# Altium schematic sheet bmsMaster_isospi.SchDoc
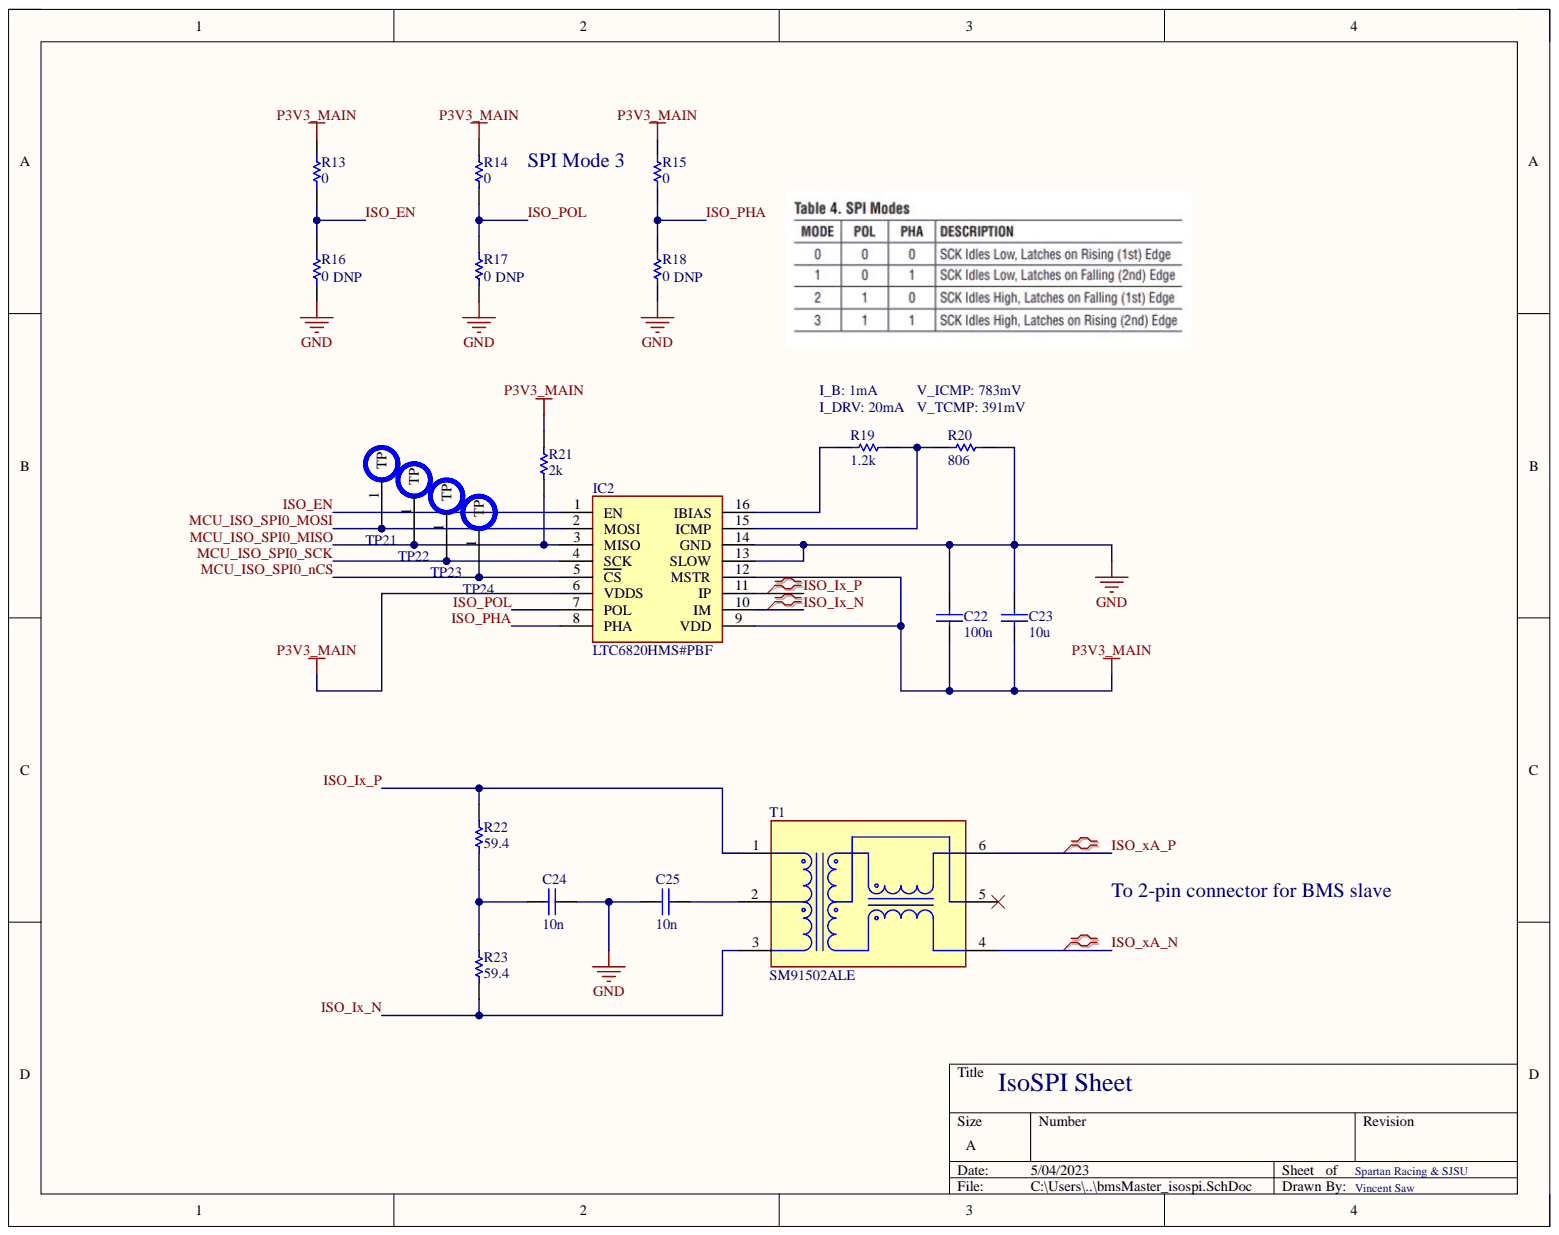
[diagram p0: bmsMaster_isospi.SchDoc]

## Parts
C22  value 100n  (hidden comment: Capacitor)
C23  value 10u  (hidden comment: Capacitor)
C24  value 10n  (hidden comment: Capacitor)
C25  value 10n  (hidden comment: Capacitor)
IC2  LTC6820HMS#PBF  [SOP50P490X110-16N]
R13  value 0  (hidden comment: Resistor)
R14  value 0  (hidden comment: Resistor)
R15  value 0  (hidden comment: Resistor)
R16  value 0  (hidden comment: Resistor)
R17  value 0  (hidden comment: Resistor)
R18  value 0  (hidden comment: Resistor)
R19  value 1.2k  (hidden comment: Resistor)
R20  value 806  (hidden comment: Resistor)
R21  value 2k  (hidden comment: Resistor)
R22  value 59.4  (hidden comment: Resistor)
R23  value 59.4  (hidden comment: Resistor)
T1  SM91502ALE  [FP-SM91502ALE-MFG]
TP21  TSTPT  [Testpoint1]  (value not drawn)  (no BOM)
TP22  TSTPT  [Testpoint1]  (value not drawn)  (no BOM)
TP23  TSTPT  [Testpoint1]  (value not drawn)  (no BOM)
TP24  TSTPT  [Testpoint1]  (value not drawn)  (no BOM)

## Nets
GND: C22.2 C23.2 C24.1 C25.1 IC2.13(SLOW) IC2.14(GND) R16.1 R17.1 R18.1 R20.2
P3V3_MAIN: C22.1 C23.1 IC2.6(VDDS) IC2.9(VDD) IC2.12(MSTR) R13.2 R14.2 R15.2 R21.2
ISO_EN: IC2.1(EN) R13.1 R16.2
ISO_Ix_N: IC2.10(IM) R23.1 T1.3
ISO_Ix_P: IC2.11(IP) R22.2 T1.1
ISO_PHA: IC2.8(PHA) R15.1 R18.2
ISO_POL: IC2.7(POL) R14.1 R17.2
ISO_xA_N: T1.4
ISO_xA_P: T1.6
MCU_ISO_SPI0_MISO: IC2.3(MISO) R21.1 TP22.1
MCU_ISO_SPI0_MOSI: IC2.2(MOSI) TP21.1
MCU_ISO_SPI0_nCS: IC2.5(CS) TP24.1
MCU_ISO_SPI0_SCK: IC2.4(SCK) TP23.1
NetC24_2: C24.2 R22.1 R23.2
NetC25_2: C25.2 T1.2
NetIC2_15: IC2.15(ICMP) R19.2 R20.1
NetIC2_16: IC2.16(IBIAS) R19.1

Not connected: T1 5

## Library data of the parts
C22-C25 = Capacitor: SimulationGenericComponent: True; Component Kind: Standard; Description: Capacitor; Library Name: Simulation Generic Components.IntLib; Simulation: C_Capacitor_Default
IC2 = LTC6820HMS#PBF: Integrated Circuit; Manufacturer_Name: Analog Devices; Arrow Part Number: LTC6820HMS#PBF; Arrow Price/Stock: https://www.arrow.com/en/products/ltc6820hmspbf/analog-devices?region=europe; Component Kind: Standard; Datasheet Link: https://www.analog.com/media/en/technical-documentation/data-sheets/LTC6820.pdf; Height: 1.1mm; Manufacturer_Part_Number: LTC6820HMS#PBF; Mouser Part Number: 584-LTC6820HMS#PBF; Mouser Price/Stock: https://www.mouser.co.uk/ProductDetail/Analog-Devices/LTC6820HMSPBF?qs=hVkxg5c3xu%252BAhS55eUb%252BEQ%3D%3D
R13-R18 = Resistor: SimulationGenericComponent: True; Component Kind: Standard; Description: Resistor; Library Name: Simulation Generic Components.IntLib; Simulation: R_Resistor_Default; TC1: 0; TC2: 0
R19-R23 = Resistor: SimulationGenericComponent: True; TC1: 0; Component Kind: Standard; Description: Resistor; Library Name: Simulation Generic Components.IntLib; Simulation: R_Resistor_Default; TC2: 0
T1 = CMP-07264-000001-1: BMS XFORMER 1-CH 1000V AECQ +125; Manufacturer: Bourns; Automotive Grade: Grade 1; Insertion Loss: -0.25dB; Isolation Voltage: 4300VDC; Return Loss: -22dB; Standoff Height: 0.13mm; Turns Ratio: 1:1; Automotive: Yes; Category: Trans; Component Kind: Standard; ComponentLink2Description: Datasheet; ComponentLink2URL: https://www.bourns.com/docs/product-datasheets/SM91502AL.pdf; Device Class L1: Passive Components; Device Class L2: Transformers; Device Class L3: unset; Digikey Description: BMS XFORMER 1-CH 1000V AECQ +125; Height: 5.72mm; Lead Free: Yes; Library Name: Altium Content Vault; Manufacturer Part Number: SM91502ALE; Octopart: https://octopart.com/sm91502ale-bourns-95732123; Package: 0; RoHS: TRUE; Temperature: -40°C to +125°C; Temperature Range High: +125°C; Temperature Range Low: -40°C
TP21-TP24 = Testpoint1: Library Name: testpoint1.SchLib
